# Altium schematic sheet board.SchDoc
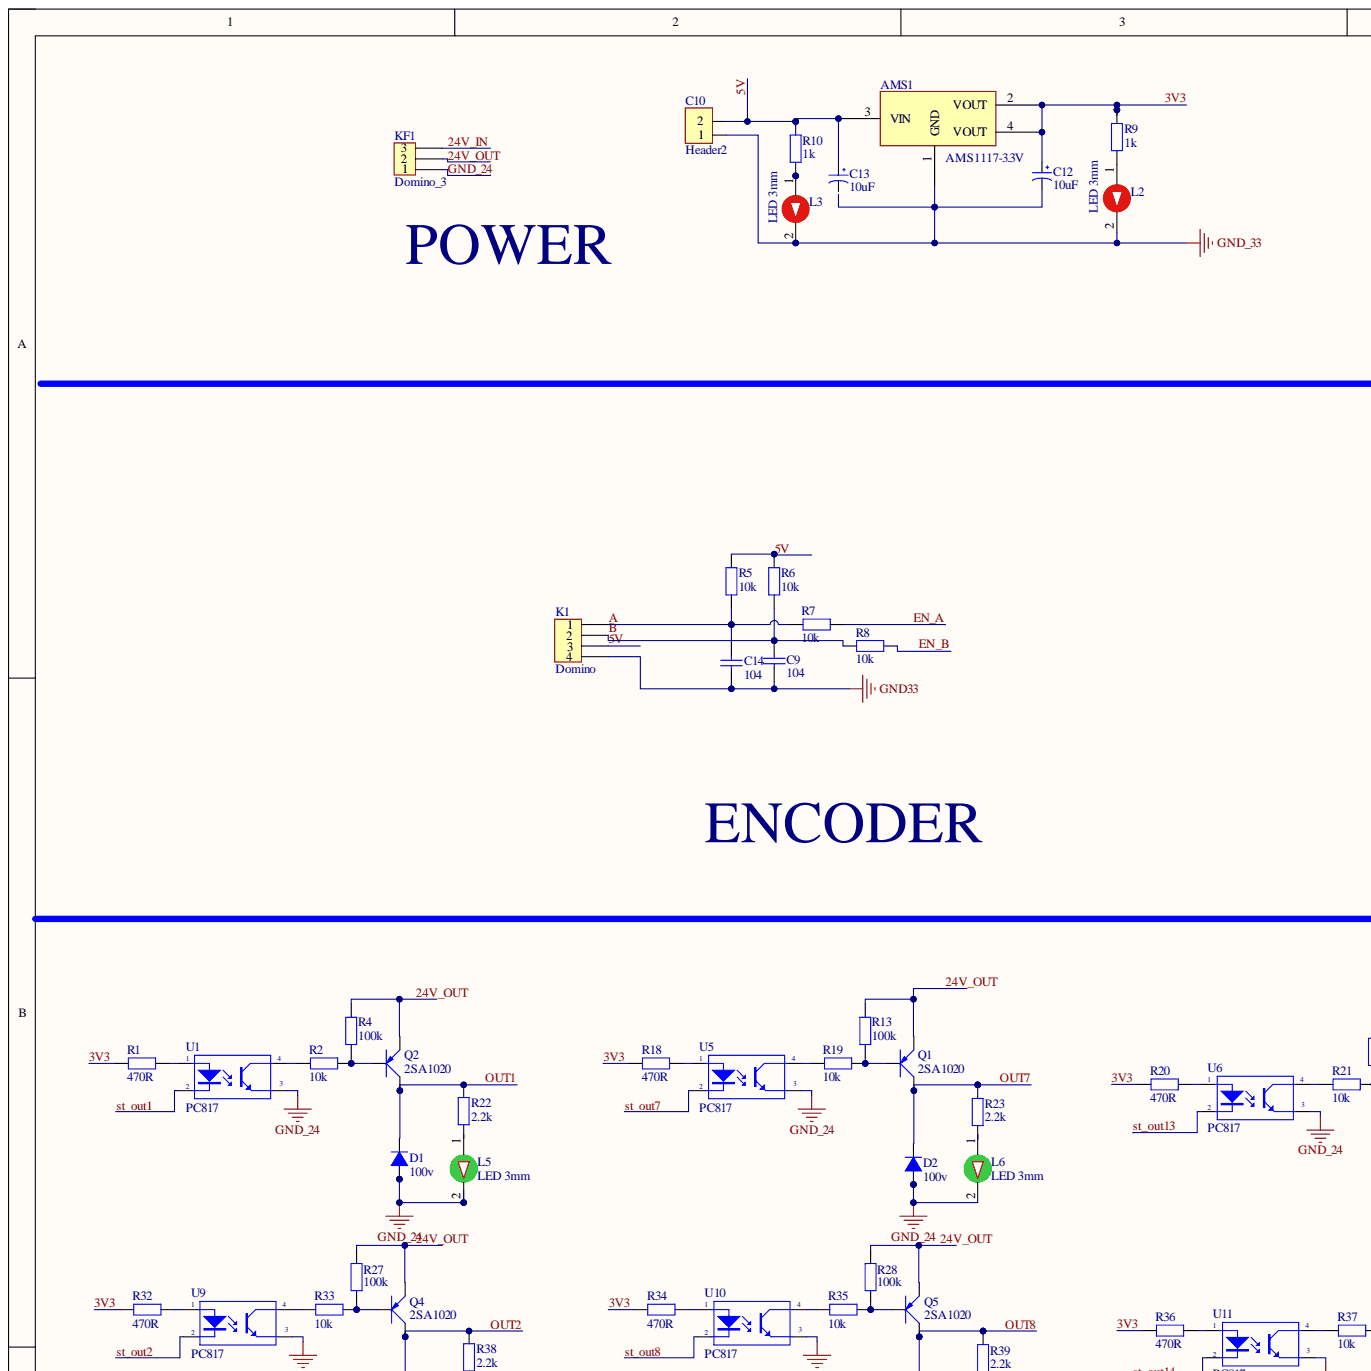
[diagram p0: board.SchDoc, top left]
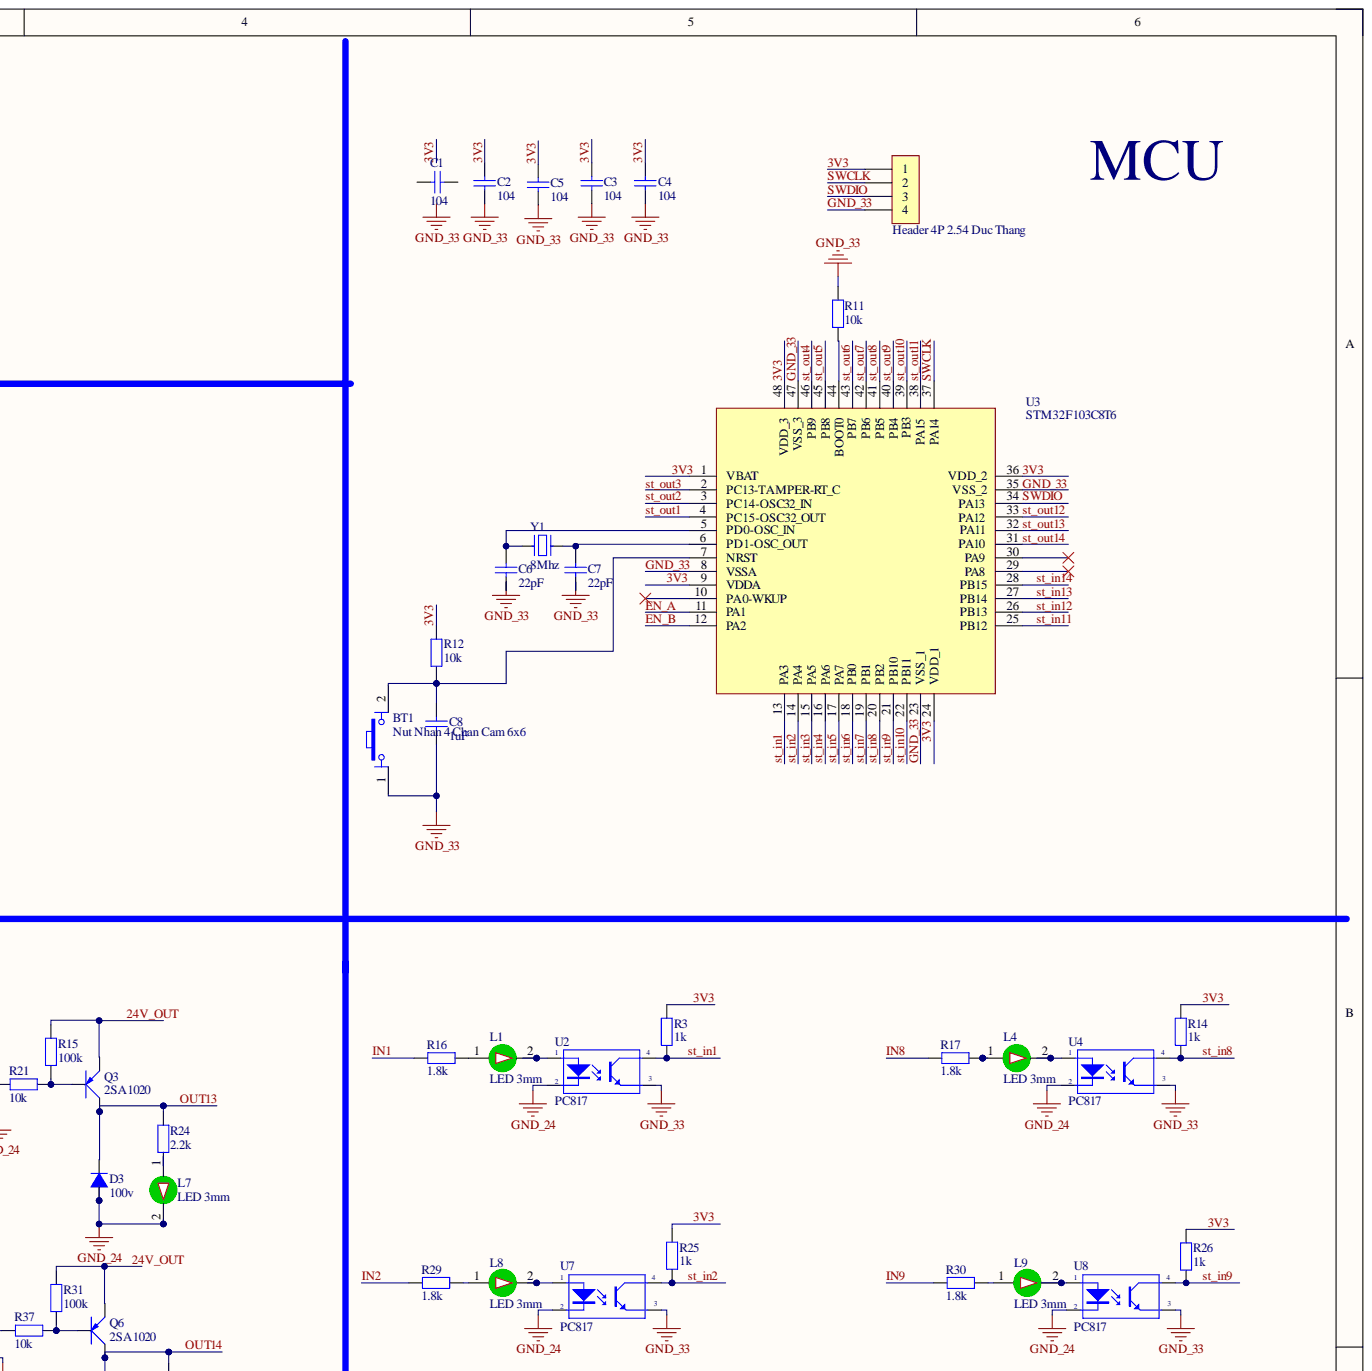
[diagram p1: board.SchDoc, top right]
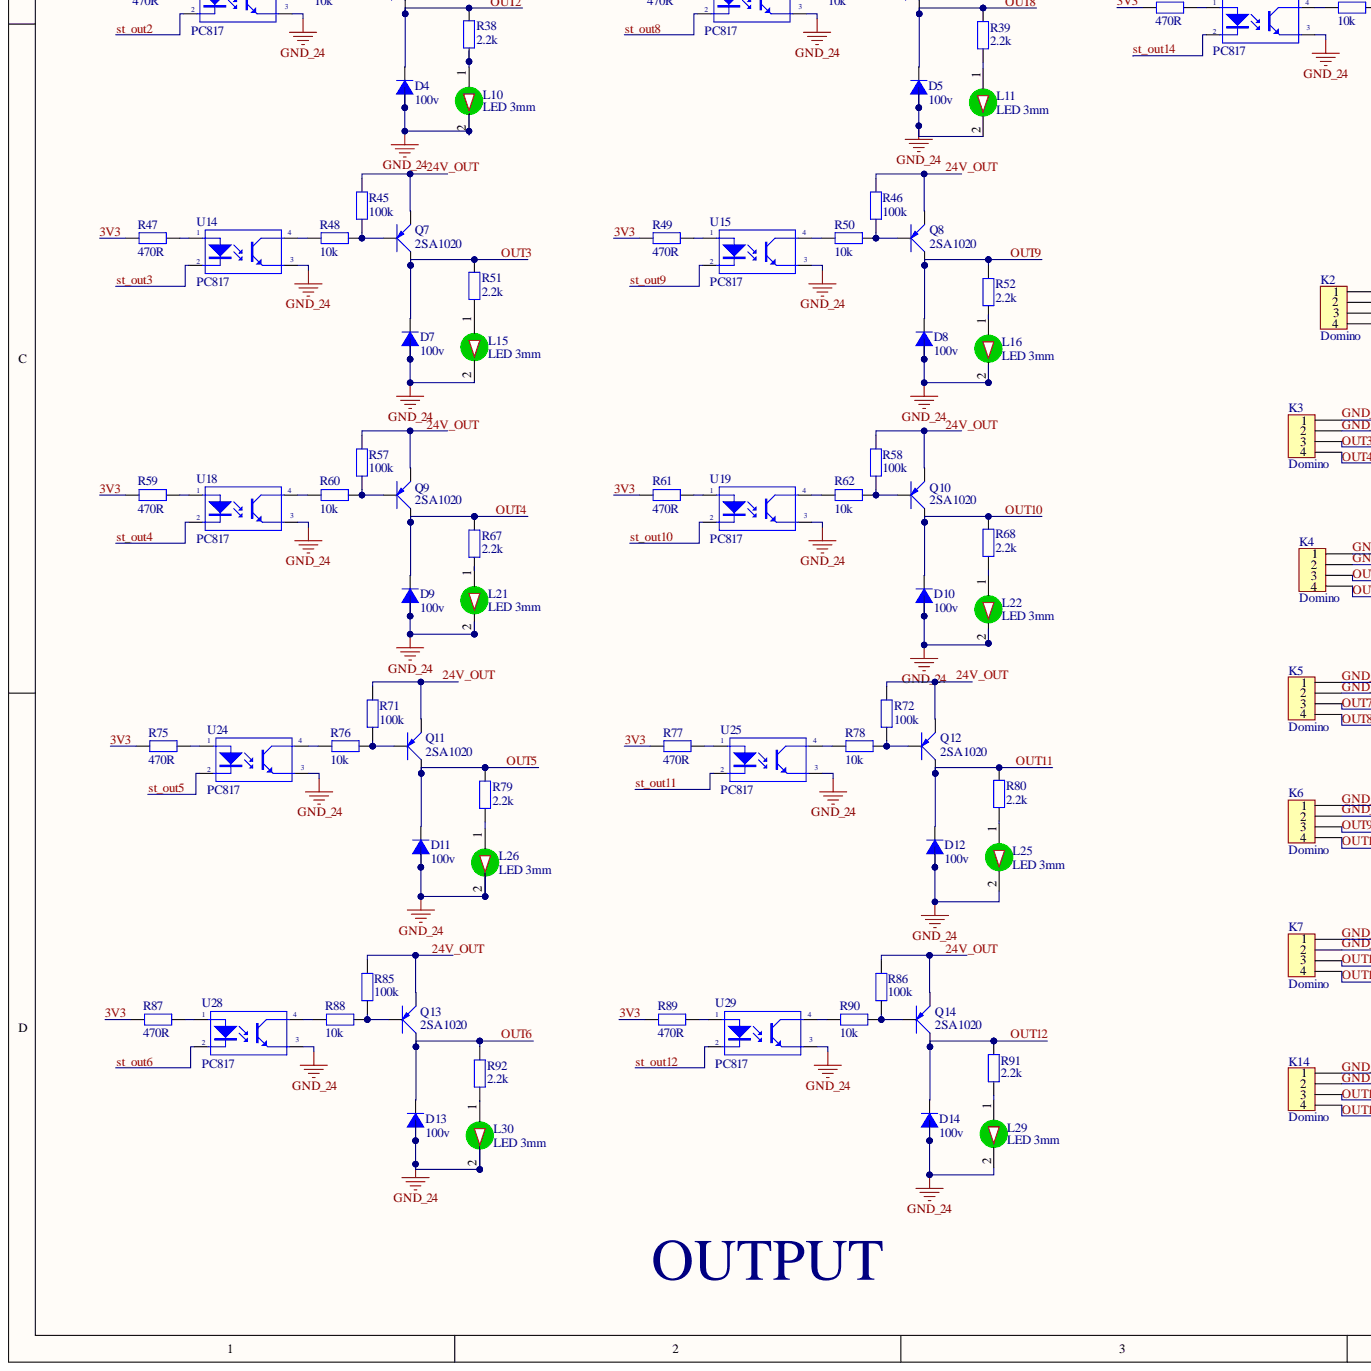
[diagram p2: board.SchDoc, bottom left]
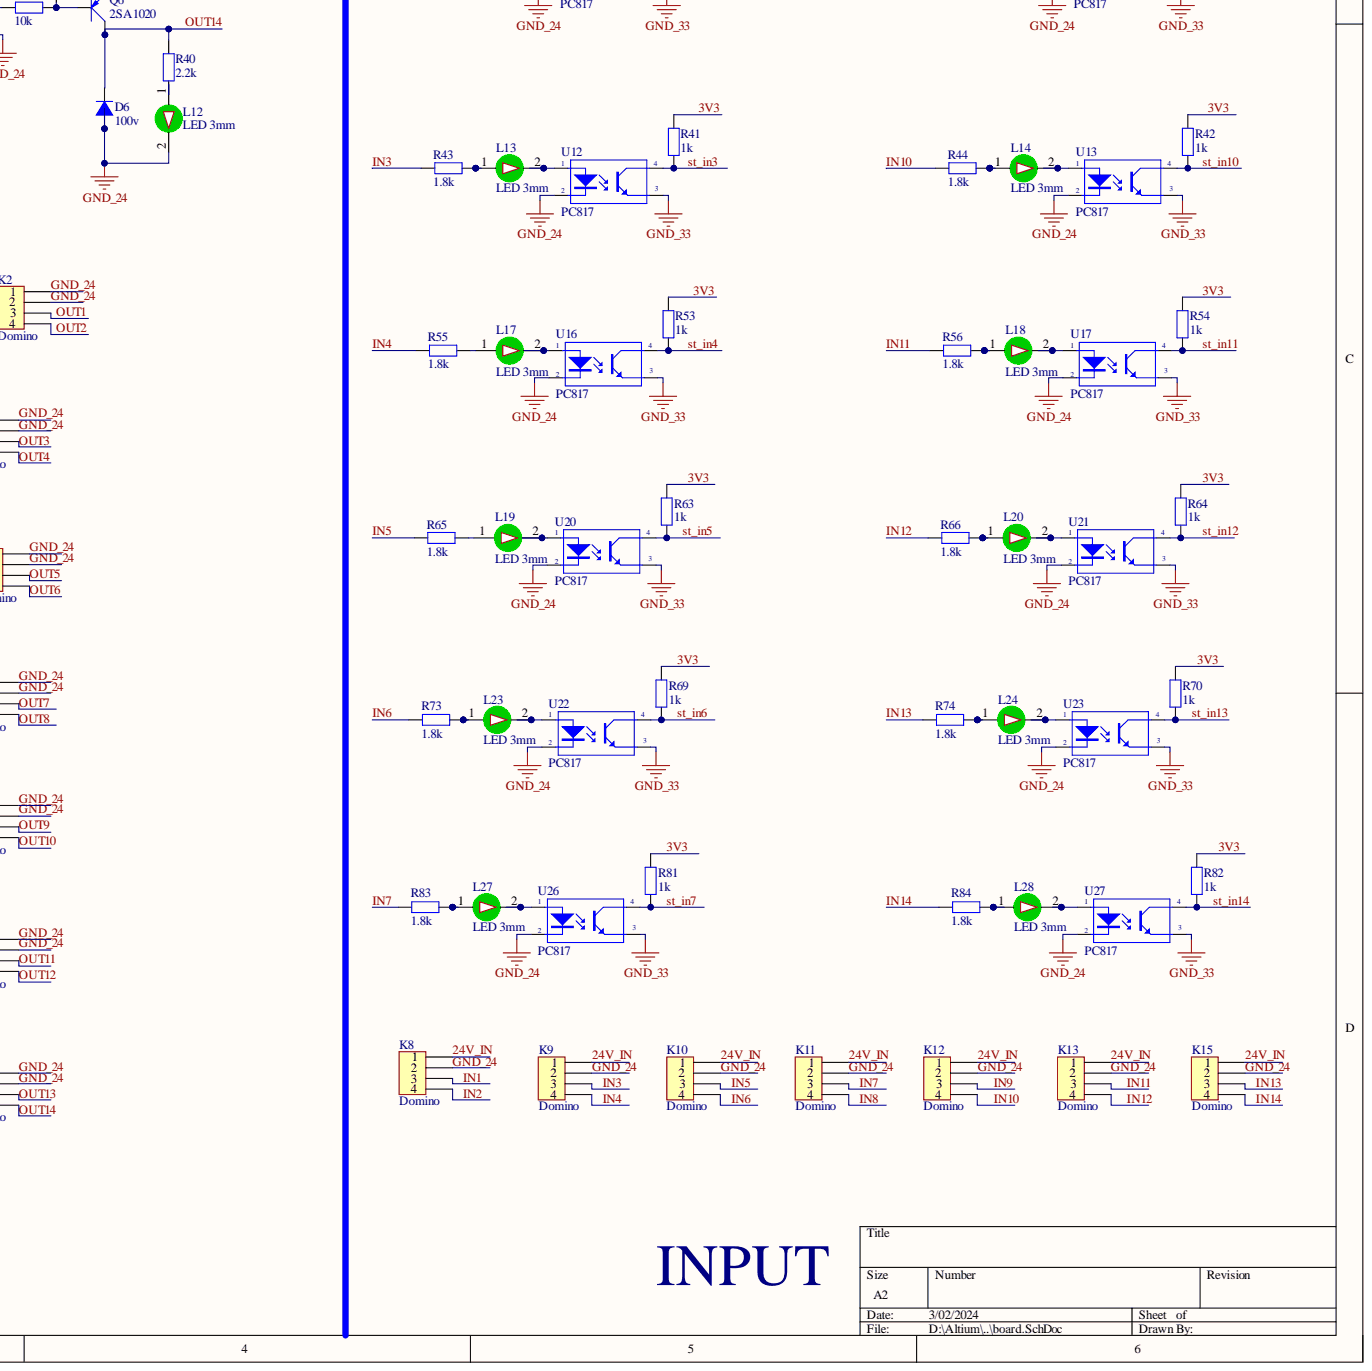
[diagram p3: board.SchDoc, bottom right]

## Parts
AMS1  AMS1117-3.3V  [SOT223]
BT1  Nut Nhan 4 Chan Cam 6x6  [Nut Nhan 4 Chan Cam 6x6]
C1  104  [tu gom]
C2  104  [tu gom]
C3  104  [tu gom]
C4  104  [tu gom]
C5  104  [tu gom]
C6  22pF  [tu gom]
C7  22pF  [tu gom]
C8  1uF  [tu tantalum dip5.08]
C9  104  [tu gom]
C10  Header2  [HDR2]
C12  10uF  [tu hoa 4mm]
C13  10uF  [tu hoa 4mm]
C14  104  [tu gom]
D1  100v  [DIODE 1A]
D2  100v  [DIODE 1A]
D3  100v  [DIODE 1A]
D4  100v  [DIODE 1A]
D5  100v  [DIODE 1A]
D6  100v  [DIODE 1A]
D7  100v  [DIODE 1A]
D8  100v  [DIODE 1A]
D9  100v  [DIODE 1A]
D10  100v  [DIODE 1A]
D11  100v  [DIODE 1A]
D12  100v  [DIODE 1A]
D13  100v  [DIODE 1A]
D14  100v  [DIODE 1A]
H1  Header 4P 2.54 Duc Thang  [XH2.54M-4]
K1  Domino  [KF128HL_2P]
K2  Domino  [KF128HL_2P]
K3  Domino  [KF128HL_2P]
K4  Domino  [KF128HL_2P]
K5  Domino  [KF128HL_2P]
K6  Domino  [KF128HL_2P]
K7  Domino  [KF128HL_2P]
K8  Domino  [KF128HL_2P]
K9  Domino  [KF128HL_2P]
K10  Domino  [KF128HL_2P]
K11  Domino  [KF128HL_2P]
K12  Domino  [KF128HL_2P]
K13  Domino  [KF128HL_2P]
K14  Domino  [KF128HL_2P]
K15  Domino  [KF128HL_2P]
KF1  Domino_3  [DOMINO_3]
L1  LED 3mm  [LED-3MM-GREEN]
L2  LED 3mm  [LED-3MM-RED]
L3  LED 3mm  [LED-3MM-RED]
L4  LED 3mm  [LED-3MM-GREEN]
L5  LED 3mm  [LED-3MM-GREEN]
L6  LED 3mm  [LED-3MM-GREEN]
L7  LED 3mm  [LED-3MM-GREEN]
L8  LED 3mm  [LED-3MM-GREEN]
L9  LED 3mm  [LED-3MM-GREEN]
L10  LED 3mm  [LED-3MM-GREEN]
L11  LED 3mm  [LED-3MM-GREEN]
L12  LED 3mm  [LED-3MM-GREEN]
L13  LED 3mm  [LED-3MM-GREEN]
L14  LED 3mm  [LED-3MM-GREEN]
L15  LED 3mm  [LED-3MM-GREEN]
L16  LED 3mm  [LED-3MM-GREEN]
L17  LED 3mm  [LED-3MM-GREEN]
L18  LED 3mm  [LED-3MM-GREEN]
L19  LED 3mm  [LED-3MM-GREEN]
L20  LED 3mm  [LED-3MM-GREEN]
L21  LED 3mm  [LED-3MM-GREEN]
L22  LED 3mm  [LED-3MM-GREEN]
L23  LED 3mm  [LED-3MM-GREEN]
L24  LED 3mm  [LED-3MM-GREEN]
L25  LED 3mm  [LED-3MM-GREEN]
L26  LED 3mm  [LED-3MM-GREEN]
L27  LED 3mm  [LED-3MM-GREEN]
L28  LED 3mm  [LED-3MM-GREEN]
L29  LED 3mm  [LED-3MM-GREEN]
L30  LED 3mm  [LED-3MM-GREEN]
Q1  2SA1020  [TO92-123]
Q2  2SA1020  [TO92-123]
Q3  2SA1020  [TO92-123]
Q4  2SA1020  [TO92-123]
Q5  2SA1020  [TO92-123]
Q6  2SA1020  [TO92-123]
Q7  2SA1020  [TO92-123]
Q8  2SA1020  [TO92-123]
Q9  2SA1020  [TO92-123]
Q10  2SA1020  [TO92-123]
Q11  2SA1020  [TO92-123]
Q12  2SA1020  [TO92-123]
Q13  2SA1020  [TO92-123]
Q14  2SA1020  [TO92-123]
R1  470R  [tro vach 1/4w]
R2  10k  [tro vach 1/4w]
R3  1k  [tro vach 1/4w]
R4  100k  [tro smd 0805]
R5  10k  [tro smd 0805]
R6  10k  [tro smd 0805]
R7  10k  [tro vach 1/4w]
R8  10k  [tro vach 1/4w]
R9  1k  [tro vach 1/4w]
R10  1k  [tro vach 1/4w]
R11  10k  [tro vach 1/4w]
R12  10k  [tro vach 1/4w]
R13  100k  [tro vach 1/4w]
R14  1k  [tro vach 1/4w]
R15  100k  [tro vach 1/4w]
R16  1.8k  [tro vach 1/4w]
R17  1.8k  [tro vach 1/4w]
R18  470R  [tro vach 1/4w]
R19  10k  [tro vach 1/4w]
R20  470R  [tro vach 1/4w]
R21  10k  [tro vach 1/4w]
R22  2.2k  [tro vach 1/4w]
R23  2.2k  [tro vach 1/4w]
R24  2.2k  [tro vach 1/4w]
R25  1k  [tro vach 1/4w]
R26  1k  [tro vach 1/4w]
R27  100k  [tro vach 1/4w]
R28  100k  [tro vach 1/4w]
R29  1.8k  [tro vach 1/4w]
R30  1.8k  [tro vach 1/4w]
R31  100k  [tro vach 1/4w]
R32  470R  [tro vach 1/4w]
R33  10k  [tro vach 1/4w]
R34  470R  [tro vach 1/4w]
R35  10k  [tro vach 1/4w]
R36  470R  [tro vach 1/4w]
R37  10k  [tro vach 1/4w]
R38  2.2k  [tro vach 1/4w]
R39  2.2k  [tro vach 1/4w]
R40  2.2k  [tro vach 1/4w]
R41  1k  [tro vach 1/4w]
R42  1k  [tro vach 1/4w]
R43  1.8k  [tro vach 1/4w]
R44  1.8k  [tro vach 1/4w]
R45  100k  [tro vach 1/4w]
R46  100k  [tro vach 1/4w]
R47  470R  [tro vach 1/4w]
R48  10k  [tro vach 1/4w]
R49  470R  [tro vach 1/4w]
R50  10k  [tro vach 1/4w]
R51  2.2k  [tro vach 1/4w]
R52  2.2k  [tro vach 1/4w]
R53  1k  [tro vach 1/4w]
R54  1k  [tro vach 1/4w]
R55  1.8k  [tro vach 1/4w]
R56  1.8k  [tro vach 1/4w]
R57  100k  [tro vach 1/4w]
R58  100k  [tro vach 1/4w]
R59  470R  [tro vach 1/4w]
R60  10k  [tro vach 1/4w]
R61  470R  [tro vach 1/4w]
R62  10k  [tro vach 1/4w]
R63  1k  [tro vach 1/4w]
R64  1k  [tro vach 1/4w]
R65  1.8k  [tro vach 1/4w]
R66  1.8k  [tro vach 1/4w]
R67  2.2k  [tro vach 1/4w]
R68  2.2k  [tro vach 1/4w]
R69  1k  [tro vach 1/4w]
R70  1k  [tro vach 1/4w]
R71  100k  [tro vach 1/4w]
R72  100k  [tro vach 1/4w]
R73  1.8k  [tro vach 1/4w]
R74  1.8k  [tro vach 1/4w]
R75  470R  [tro vach 1/4w]
R76  10k  [tro vach 1/4w]
R77  470R  [tro vach 1/4w]
R78  10k  [tro vach 1/4w]
R79  2.2k  [tro vach 1/4w]
R80  2.2k  [tro vach 1/4w]
R81  1k  [tro vach 1/4w]
R82  1k  [tro vach 1/4w]
R83  1.8k  [tro vach 1/4w]
R84  1.8k  [tro vach 1/4w]
R85  100k  [tro vach 1/4w]
R86  100k  [tro vach 1/4w]
R87  470R  [tro vach 1/4w]
R88  10k  [tro vach 1/4w]
R89  470R  [tro vach 1/4w]
R90  10k  [tro vach 1/4w]
R91  2.2k  [tro vach 1/4w]
R92  2.2k  [tro vach 1/4w]
U1  PC817  [DIP4]
U2  PC817  [DIP4]
U3  STM32F103C8T6  [LQFP-48_19.6_393_58X11_SMD]
U4  PC817  [DIP4]
U5  PC817  [DIP4]
U6  PC817  [DIP4]
U7  PC817  [DIP4]
U8  PC817  [DIP4]
U9  PC817  [DIP4]
U10  PC817  [DIP4]
U11  PC817  [DIP4]
U12  PC817  [DIP4]
U13  PC817  [DIP4]
U14  PC817  [DIP4]
U15  PC817  [DIP4]
U16  PC817  [DIP4]
U17  PC817  [DIP4]
U18  PC817  [DIP4]
U19  PC817  [DIP4]
U20  PC817  [DIP4]
U21  PC817  [DIP4]
U22  PC817  [DIP4]
U23  PC817  [DIP4]
U24  PC817  [DIP4]
U25  PC817  [DIP4]
U26  PC817  [DIP4]
U27  PC817  [DIP4]
U28  PC817  [DIP4]
U29  PC817  [DIP4]
Y1  8Mhz  [Thach Anh 2 chan cam]

## Nets
GND33: C9.2 C14.2 K1.4
3V3: AMS1.2(VOUT) AMS1.4(VOUT) C2.1 C3.1 C4.1 C5.1 C12.1 H1.1 R1.1 R3.2 R9.2 R12.2 R14.2 R18.1 R20.1 R25.2 R26.2 R32.1 R34.1 R36.1 R41.2 R42.2 R47.1 R49.1 R53.2 R54.2 R59.1 R61.1 R63.2 R64.2 R69.2 R70.2 R75.1 R77.1 R81.2 R82.2 R87.1 R89.1 U3.1(VBAT) U3.9(VDDA) U3.24(VDD_1) U3.36(VDD_2) U3.48(VDD_3)
5V: AMS1.3(VIN) C10.2 C13.1 K1.3 R5.2 R6.2 R10.2
24V_IN: K8.1 K9.1 K10.1 K11.1 K12.1 K13.1 K15.1 KF1.3
24V_OUT: KF1.2 Q1.1 Q2.1 Q3.1 Q4.1 Q5.1 Q6.1 Q7.1 Q8.1 Q9.1 Q10.1 Q11.1 Q12.1 Q13.1 Q14.1 R4.2 R13.2 R15.2 R27.2 R28.2 R31.2 R45.2 R46.2 R57.2 R58.2 R71.2 R72.2 R85.2 R86.2
A: C14.1 K1.1 R5.1 R7.1
B: C9.1 K1.2 R6.1 R8.1
EN_A: R7.2 U3.11(PA1)
EN_B: R8.2 U3.12(PA2)
GND_24: D1.1 D2.1 D3.1 D4.1 D5.1 D6.1 D7.1 D8.1 D9.1 D10.1 D11.1 D12.1 D13.1 D14.1 K2.1 K2.2 K3.1 K3.2 K4.1 K4.2 K5.1 K5.2 K6.1 K6.2 K7.1 K7.2 K8.2 K9.2 K10.2 K11.2 K12.2 K13.2 K14.1 K14.2 K15.2 KF1.1 L5.2 L6.2 L7.2 L10.2 L11.2 L12.2 L15.2 L16.2 L21.2 L22.2 L25.2 L26.2 L29.2 L30.2 U1.3 U2.2 U4.2 U5.3 U6.3 U7.2 U8.2 U9.3 U10.3 U11.3 U12.2 U13.2 U14.3 U15.3 U16.2 U17.2 U18.3 U19.3 U20.2 U21.2 U22.2 U23.2 U24.3 U25.3 U26.2 U27.2 U28.3 U29.3
GND_33: AMS1.1(GND) BT1.1 C2.2 C3.2 C4.2 C5.2 C6.2 C7.2 C8.2 C10.1 C12.2 H1.4 L2.2 L3.2 R11.2 U2.3 U3.8(VSSA) U3.23(VSS_1) U3.35(VSS_2) U3.47(VSS_3) U4.3 U7.3 U8.3 U12.3 U13.3 U16.3 U17.3 U20.3 U21.3 U22.3 U23.3 U26.3 U27.3
IN1: K8.3 R16.1
IN2: K8.4 R29.1
IN3: K9.3 R43.1
IN4: K9.4 R55.1
IN5: K10.3 R65.1
IN6: K10.4 R73.1
IN7: K11.3 R83.1
IN8: K11.4 R17.1
IN9: K12.3 R30.1
IN10: K12.4 R44.1
IN11: K13.3 R56.1
IN12: K13.4 R66.1
IN13: K15.3 R74.1
IN14: K15.4 R84.1
OUT1: D1.2 K2.3 Q2.2 R22.2
OUT2: D4.2 K2.4 Q4.2 R38.2
OUT3: D7.2 K3.3 Q7.2 R51.2
OUT4: D9.2 K3.4 Q9.2 R67.2
OUT5: D11.2 K4.3 Q11.2 R79.2
OUT6: D13.2 K4.4 Q13.2 R92.2
OUT7: D2.2 K5.3 Q1.2 R23.2
OUT8: D5.2 K5.4 Q5.2 R39.2
OUT9: D8.2 K6.3 Q8.2 R52.2
OUT10: D10.2 K6.4 Q10.2 R68.2
OUT11: D12.2 K7.3 Q12.2 R80.2
OUT12: D14.2 K7.4 Q14.2 R91.2
OUT13: D3.2 K14.3 Q3.2 R24.2
OUT14: D6.2 K14.4 Q6.2 R40.2
st_in1: R3.1 U2.4 U3.13(PA3)
st_in2: R25.1 U3.14(PA4) U7.4
st_in3: R41.1 U3.15(PA5) U12.4
st_in4: R53.1 U3.16(PA6) U16.4
st_in5: R63.1 U3.17(PA7) U20.4
st_in6: R69.1 U3.18(PB0) U22.4
st_in7: R81.1 U3.19(PB1) U26.4
st_in8: R14.1 U3.20(PB2) U4.4
st_in9: R26.1 U3.21(PB10) U8.4
st_in10: R42.1 U3.22(PB11) U13.4
st_in11: R54.1 U3.25(PB12) U17.4
st_in12: R64.1 U3.26(PB13) U21.4
st_in13: R70.1 U3.27(PB14) U23.4
st_in14: R82.1 U3.28(PB15) U27.4
st_out1: U1.2 U3.4(PC15-OSC32_OUT)
st_out2: U3.3(PC14-OSC32_IN) U9.2
st_out3: U3.2(PC13-TAMPER-RT_C) U14.2
st_out4: U3.46(PB9) U18.2
st_out5: U3.45(PB8) U24.2
st_out6: U3.43(PB7) U28.2
st_out7: U3.42(PB6) U5.2
st_out8: U3.41(PB5) U10.2
st_out9: U3.40(PB4) U15.2
st_out10: U3.39(PB3) U19.2
st_out11: U3.38(PA15) U25.2
st_out12: U3.33(PA12) U29.2
st_out13: U3.32(PA11) U6.2
st_out14: U3.31(PA10) U11.2
SWCLK: H1.2 U3.37(PA14)
SWDIO: H1.3 U3.34(PA13)
NetBT1_2: BT1.2 C8.1 R12.1 U3.7(NRST)
NetC6_1: C6.1 U3.5(PD0-OSC_IN) Y1.2
NetC7_1: C7.1 U3.6(PD1-OSC_OUT) Y1.1
NetL1_1: L1.1 R16.2
NetL1_2: L1.2 U2.1
NetL2_1: L2.1 R9.1
NetL3_1: L3.1 R10.1
NetL4_1: L4.1 R17.2
NetL4_2: L4.2 U4.1
NetL5_1: L5.1 R22.1
NetL6_1: L6.1 R23.1
NetL7_1: L7.1 R24.1
NetL8_1: L8.1 R29.2
NetL8_2: L8.2 U7.1
NetL9_1: L9.1 R30.2
NetL9_2: L9.2 U8.1
NetL10_1: L10.1 R38.1
NetL11_1: L11.1 R39.1
NetL12_1: L12.1 R40.1
NetL13_1: L13.1 R43.2
NetL13_2: L13.2 U12.1
NetL14_1: L14.1 R44.2
NetL14_2: L14.2 U13.1
NetL15_1: L15.1 R51.1
NetL16_1: L16.1 R52.1
NetL17_1: L17.1 R55.2
NetL17_2: L17.2 U16.1
NetL18_1: L18.1 R56.2
NetL18_2: L18.2 U17.1
NetL19_1: L19.1 R65.2
NetL19_2: L19.2 U20.1
NetL20_1: L20.1 R66.2
NetL20_2: L20.2 U21.1
NetL21_1: L21.1 R67.1
NetL22_1: L22.1 R68.1
NetL23_1: L23.1 R73.2
NetL23_2: L23.2 U22.1
NetL24_1: L24.1 R74.2
NetL24_2: L24.2 U23.1
NetL25_1: L25.1 R80.1
NetL26_1: L26.1 R79.1
NetL27_1: L27.1 R83.2
NetL27_2: L27.2 U26.1
NetL28_1: L28.1 R84.2
NetL28_2: L28.2 U27.1
NetL29_1: L29.1 R91.1
NetL30_1: L30.1 R92.1
NetQ1_3: Q1.3 R13.1 R19.2
NetQ2_3: Q2.3 R2.2 R4.1
NetQ3_3: Q3.3 R15.1 R21.2
NetQ4_3: Q4.3 R27.1 R33.2
NetQ5_3: Q5.3 R28.1 R35.2
NetQ6_3: Q6.3 R31.1 R37.2
NetQ7_3: Q7.3 R45.1 R48.2
NetQ8_3: Q8.3 R46.1 R50.2
NetQ9_3: Q9.3 R57.1 R60.2
NetQ10_3: Q10.3 R58.1 R62.2
NetQ11_3: Q11.3 R71.1 R76.2
NetQ12_3: Q12.3 R72.1 R78.2
NetQ13_3: Q13.3 R85.1 R88.2
NetQ14_3: Q14.3 R86.1 R90.2
NetR1_2: R1.2 U1.1
NetR2_1: R2.1 U1.4
NetR11_1: R11.1 U3.44(BOOT0)
NetR18_2: R18.2 U5.1
NetR19_1: R19.1 U5.4
NetR20_2: R20.2 U6.1
NetR21_1: R21.1 U6.4
NetR32_2: R32.2 U9.1
NetR33_1: R33.1 U9.4
NetR34_2: R34.2 U10.1
NetR35_1: R35.1 U10.4
NetR36_2: R36.2 U11.1
NetR37_1: R37.1 U11.4
NetR47_2: R47.2 U14.1
NetR48_1: R48.1 U14.4
NetR49_2: R49.2 U15.1
NetR50_1: R50.1 U15.4
NetR59_2: R59.2 U18.1
NetR60_1: R60.1 U18.4
NetR61_2: R61.2 U19.1
NetR62_1: R62.1 U19.4
NetR75_2: R75.2 U24.1
NetR76_1: R76.1 U24.4
NetR77_2: R77.2 U25.1
NetR78_1: R78.1 U25.4
NetR87_2: R87.2 U28.1
NetR88_1: R88.1 U28.4
NetR89_2: R89.2 U29.1
NetR90_1: R90.1 U29.4

Not connected: C1 1 2; C13 2; U3 10(PA0-WKUP) 29(PA8) 30(PA9)

## Library data of the parts
AMS1 = AMS1117 SMD: Dán; Don_Vi: Con; Ma_Hang: MH000596; Value: AMS1117-3.3V SOT223; Chung_Loai: Dán; Component Kind: Standard; Library Name: Altium_Lib_by_KhueNguyenCreator.IntLib
BT1 = Nut Nhan 4 Chan Cam 6x6: Nut Nhan 4 Chan 6x6mm; Ma_Hang: MH004584; Ten: Nút Nhấn 4 Chân 6x6x5MM DIP; Component Kind: Standard; Library Name: Altium_Lib_by_KhueNguyenCreator.IntLib
C1-C5 C9 C14 = Tu gom 104 50V: Cắm; Don_Vi: Con; Ma_Hang: MH004761; So_Chan: 2; Ten_Linh_Kien: Tụ gốm 104 100nF 50V; Chung_Loai: Cắm; Component Kind: Standard; Library Name: Altium_Lib_by_KhueNguyenCreator.IntLib
C6 C7 = Tu gom 22pF 50V: Cắm; Don_Vi: Con; Ma_Hang: MH004771; So_Chan: 2; Ten_Linh_Kien: Tụ gốm 22P 50V; Chung_Loai: Cắm; Component Kind: Standard; Library Name: Altium_Lib_by_KhueNguyenCreator.IntLib
C8 = Tu tantalum 1uF DIP5.08: Cắm; Don_Vi: Con; Ma_Hang: MH007470; So_Chan: 2; Ten_Linh_Kien: Tụ tantalum 105-1uF DIP5.08 sứ vàng; Chung_Loai: Cắm; Component Kind: Standard; Library Name: Altium_Lib_by_KhueNguyenCreator.IntLib
C10 = Header2: Header IDC, 2 x 1 Pin Vertical 2.54mm Pitch (Non-Boxed, Male); Manufacturer: W¨¹rth Elektronik eiSos GmbH; partNo: 613002143121; ComponentLink1 Description: Manufacturer; ComponentLink2 Description: Datasheet; NoPins: 02; Component Kind: Standard; ComponentLink1URL: http://www.we-online.com; ComponentLink2URL: http://katalog.we-online.de/em/datasheet/6130xx143121.pdf; Created by: Karrer Zheng; Created Date: 29 March 2013; Library Name: TechMT.VN_Lib_Project v2.IntLib
C12 C13 = Tu Hoa 10uF 25V 4x7: Cắm; Don_Vi: Con; Ma_Hang: MH001349; So_Chan: 2; Ten_Linh_Kien: Tụ Hóa 10uF 25V 4x7MM; Chung_Loai: Cắm; Component Kind: Standard; Library Name: Altium_Lib_by_KhueNguyenCreator.IntLib
D1-D14 = Diode 1N4007: Cắm; Don_Vi: Con; Ma_Hang: MH001063; Ten_Linh_Kien: Diode 1N4007 1A (DIP); Chung_Loai: Cắm; Component Kind: Standard; Library Name: Altium_Lib_by_KhueNguyenCreator.IntLib
H1 = Header 4P 2.54 Duc Thang: Cắm; Don_Vi: Con; Ma_Hang: MH001285; Ten_Linh_Kien: Header 4P XH2.54-4P Đực Thẳng; Chung_Loai: Cắm; Component Kind: Standard; Library Name: Altium_Lib_by_KhueNguyenCreator.IntLib
K1-K15 = KF128HL_2P: Component Kind: Standard; Description: Domino; Library Name: My_lib.SchLib
KF1 = Domino_3: Domino 3; Component Kind: Standard; Library Name: My_lib.SchLib
L1 L4-L30 = LED 3mm Xanh La: Cắm; Don_Vi: Con; Ma_Hang: MH001054; Ten_Linh_Kien: Led 3MM Phủ Xanh (10c); Chung_Loai: Cắm; Component Kind: Standard; Library Name: Altium_Lib_by_KhueNguyenCreator.IntLib
L2 L3 = LED 3mm Do: Cắm; Don_Vi: Con; Ma_Hang: MH003892; Ten_Linh_Kien: Led 3MM Phủ Đỏ (10c); Chung_Loai: Cắm; Component Kind: Standard; Library Name: Altium_Lib_by_KhueNguyenCreator.IntLib
Q1-Q14 = 2SA1015: PNP 600mW, ECB; Value: A1015; Component Kind: Standard; Library Name: TechMT.VN_Lib_Project v2.IntLib
R1 R18 R20 R32 R34 R36 R47 R49 R59 R61 R75 R77 R87 R89 = Tro Vach 1/4W 5% 470R: Cắm; Don_Vi: Con; Ma_Hang: MH007320; So_Chan: 2; Ten_Linh_Kien: Trở Vạch 1/4w 5% 470R; Chung_Loai: Cắm; Component Kind: Standard; Library Name: Altium_Lib_by_KhueNguyenCreator.IntLib
R2 R7 R8 R19 R21 R33 R35 R37 R48 R50 R60 R62 R76 R78 R88 R90 = Tro Vach 1/4W 5% 10k: Căm; Chung_Loai: Cắm; Don_Vi: Con; Ma_Hang: MH007354; So_Chan: 2; Ten_Linh_Kien: Trở Vạch 1/4w 5% 10K; Component Kind: Standard; Library Name: Altium_Lib_by_KhueNguyenCreator.IntLib
R3 R9 R10 R14 R25 R26 R41 R42 R53 R54 R63 R64 R69 R70 R81 R82 = Tro Vach 1/4W 5% 1k: Cắm; Don_Vi: Con; Ma_Hang: MH007390; So_Chan: 2; Ten_Linh_Kien: Trở Vạch 1/4w 5% 1k; Chung_Loai: Cắm; Component Kind: Standard; Library Name: Altium_Lib_by_KhueNguyenCreator.IntLib
R4-R6 = Tro 0805 1% 10k: Dán; Don_Vi: Con; Ma_Hang: MH005473; So_Chan: 2; Ten_Linh_Kien: Trở 0805 1% 10k; Chung_Loai: Dán; Component Kind: Standard; Library Name: Altium_Lib_by_KhueNguyenCreator.IntLib
R11 R12 = Tro Vach 1/4W 1% 10k: Cắm; Don_Vi: Con; Ma_Hang: MH006349; So_Chan: 2; Ten_Linh_Kien: Trở Vạch 1/4w 1% 10k; Chung_Loai: Cắm; Component Kind: Standard; Library Name: Altium_Lib_by_KhueNguyenCreator.IntLib
R13 R15 R27 R28 R31 R45 R46 R57 R58 R71 R72 R85 R86 = Tro Vach 1/4W 5% 100k: Cắm; Don_Vi: Con; Ma_Hang: MH007352; So_Chan: 2; Ten_Linh_Kien: Trở Vạch 1/4w 5% 100k; Chung_Loai: Cắm; Component Kind: Standard; Library Name: Altium_Lib_by_KhueNguyenCreator.IntLib
R16 R17 R29 R30 R43 R44 R55 R56 R65 R66 R73 R74 R83 R84 = Tro Vach 1/4W 5% 1.8k: Cắm; Don_Vi: Con; Ma_Hang: MH007285; So_Chan: 2; Ten_Linh_Kien: Trở Vạch 1/4w 5% 1.8k; Chung_Loai: Cắm; Component Kind: Standard; Library Name: Altium_Lib_by_KhueNguyenCreator.IntLib
R22-R24 R38-R40 R51 R52 R67 R68 R79 R80 R91 R92 = Tro Vach 1/4W 5% 2.2k: Cắm; Don_Vi: Con; Ma_Hang: MH007293; So_Chan: 2; Ten_Linh_Kien: Trở Vạch 1/4w 5% 2.2k; Chung_Loai: Cắm; Component Kind: Standard; Library Name: Altium_Lib_by_KhueNguyenCreator.IntLib
U1 U2 U4-U29 = PC817: Single Opto; Component Kind: Standard; Library Name: TechMT.VN_Lib_Project v2.IntLib; Value: PC817
U3 = IC_STM32F103C8T6: ARM® Cortex®-M3 STM32F1 Microcontroller IC 32-Bit 72MHz 64KB (64K x 8) FLASH 48-LQFP (7x7); Alternate Part Number-1: NA; Manufacturer: STMicroelectronics; Case/Package: LQFP48; Component Kind: Standard; Library Name: Altium_Lib_by_KhueNguyenCreator.IntLib; Manufacturer Part Number: STM32F103C8T6; Supplier Part Number-1: STM32F103C8T6; Supplier-1: LCSC
Y1 = Thach Anh 2 chan Cam: Crystal For all Crystal 2pin.; Value: 4Mhz; Component Kind: Standard; Library Name: Altium_Lib_by_KhueNguyenCreator.IntLib
